# Altium schematic sheet current monitor.SchDoc
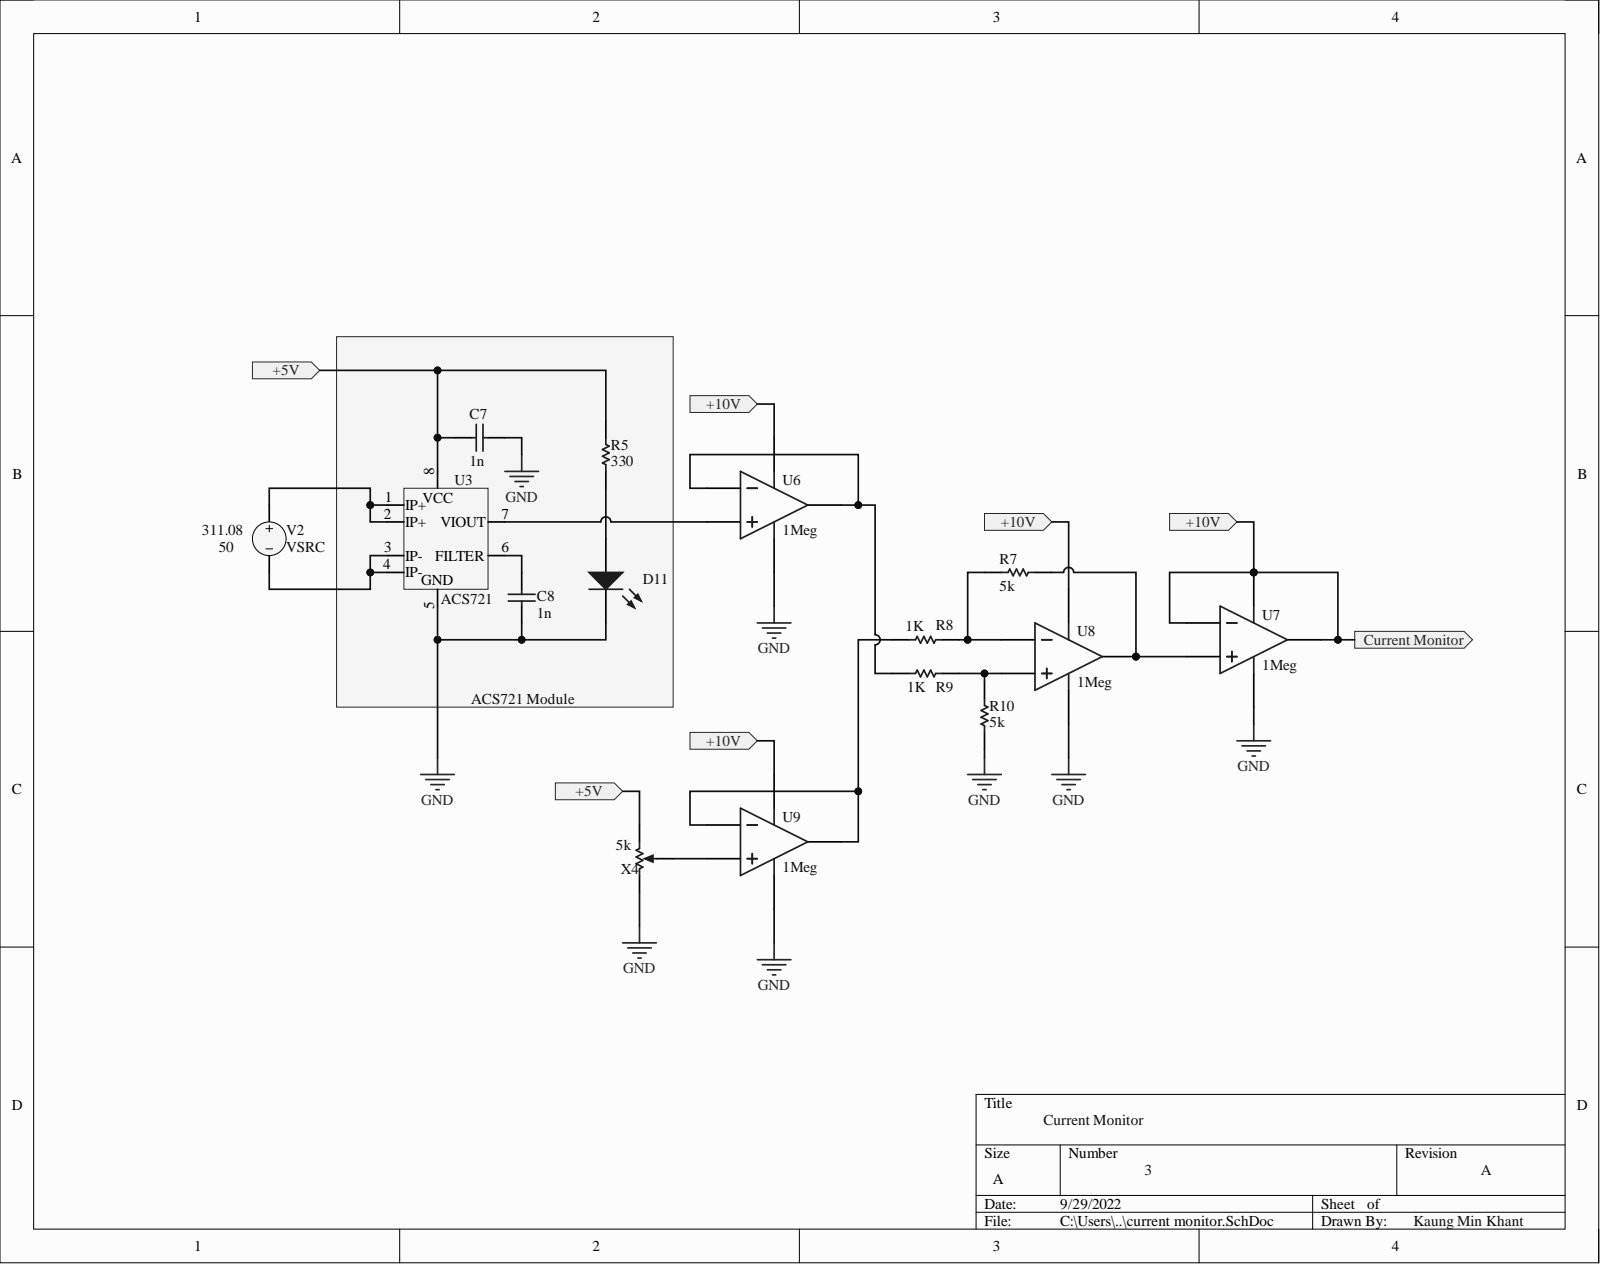
[diagram p0: current monitor.SchDoc]

## Parts
C7  value 1n  (hidden comment: Capacitor)
C8  value 1n  (hidden comment: Capacitor)
D11  Light-Emitting Diode  (value not drawn)
R5  value 330  (hidden comment: Resistor)
R7  value 5k  (hidden comment: Resistor)
R8  value 1K  (hidden comment: Resistor)
R9  value 1K  (hidden comment: Resistor)
R10  value 5k  (hidden comment: Resistor)
U3  ACS721
U6  GAIN: 1Meg  (hidden comment: Ideal Operational Amplifier)
U7  GAIN: 1Meg  (hidden comment: Ideal Operational Amplifier)
U8  GAIN: 1Meg  (hidden comment: Ideal Operational Amplifier)
U9  GAIN: 1Meg  (hidden comment: Ideal Operational Amplifier)
V2  VSRC  Amplitude: 311.08
X4  value 5k  (hidden comment: Potentiometer)

## Ports
+5V, +10V, Current Monitor

## Nets
GND: C7.1 C8.1 D11.2 R10.1 U3.5 U6.5 U7.5 U8.5 U9.5 X4.2
+5V: C7.2 R5.2 U3.8 X4.1 ; port +5V
+10V: U6.4 U7.1 U7.3 U7.4 U8.4 U9.4 ; port +10V, port Current Monitor
NetC8_2: C8.2 U3.6(FILTER)
NetD11_1: D11.1 R5.1
NetR7_1: R7.1 R8.2 U8.1
NetR7_2: R7.2 U7.2 U8.3
NetR8_1: R8.1 U9.1 U9.3
NetR9_1: R9.1 U6.1 U6.3
NetR9_2: R9.2 R10.2 U8.2
NetU3_1: U3.1(IP+) U3.2(IP+) V2.1
NetU3_3: U3.3(IP-) U3.4(IP-) V2.2
NetU3_7: U3.7(VIOUT) U6.2
NetU9_2: U9.2 X4.3

## Library data of the parts
C7 C8 = Capacitor: SimulationGenericComponent: True; Component Kind: Standard; Description: Capacitor; Library Name: Simulation Generic Components.IntLib; Simulation: C_Capacitor_Default
D11 = LED: AF: 1; BV: 0; BV_MAX: 1e99; EG: 1.11; FC: 0.5; IBV: 0.001; IS: 1e-14; MJSW: 0.33; NTUN: 30; SimulationGenericComponent: True; TNOM: 27; XTI: 3; CJO: 0; CJP: 0; Component Kind: Standard; CTA: 0; CTP: 0; Description: Light-Emitting Diode; FCS: 0.5; FV_MAX: 1e99; IKF: 0; IKR: 0; ISR: 1e-14; JSW: 0; JTUN: 0; JTUNSW: 0; KEG: 1; KF: 0; LEVEL: 1; Library Name: Simulation Generic Components.IntLib; N: 1; NBV: 1; NR: 1; NS: 1; PHP: 1; RS: 0; Simulation: diode; TCV: 0; TLEV: 0; TLEVC: 0; TM1: 0; TM2: 0; TPB: 0; TPHP: 0; TRS: 0; TRS2: 0; TT: 0; TTT1: 0; TTT2: 0; VJ: 1; XTITUN: 3
R5 R8-R10 = Resistor: SimulationGenericComponent: True; TC1: 0; Component Kind: Standard; Description: Resistor; Library Name: Simulation Generic Components.IntLib; Simulation: R_Resistor_Default; TC2: 0
R7 = Resistor: SimulationGenericComponent: True; TC1: 0; Value: 5k; Component Kind: Standard; Description: Resistor; Library Name: Simulation Generic Components.IntLib; Simulation: R_Resistor_Default; TC2: 0
U6-U9 = OpAmp with power terminals: SimulationGenericComponent: True; Component Kind: Standard; Description: Ideal Operational Amplifier; Library Name: Simulation Generic Components.IntLib; Simulation: IDEALOPAMP_5NODES
V2 = VSRC: Voltage Source; AC Magnitude: 1; AC Phase: 0; DC Magnitude: 5; Frequency: 50; Note: PCB Footprint - Not required; Component Kind: Standard; Damping Factor: 0; Delay: 0; LatestRevisionDate: 30-July-2020; LatestRevisionNote: Re-released for DXP Platform.; Library Name: Simulation Generic Components.IntLib; Offset: 0; PackageReference: Not Applicable; Phase: 0; Published: 30-July-2020; Simulation: VSRC; Publisher: Altium Limited
X4 = Potentiometer: position: 0.5; SimulationGenericComponent: True; Component Kind: Standard; Description: Potentiometer; Library Name: Simulation Generic Components.IntLib; Simulation: POT
U3 = ACS721: Component Kind: Standard; Library Name: safegaurd_schLib.SchLib
